# Altium schematic sheet Mainboard.SchDoc(Mainboard)
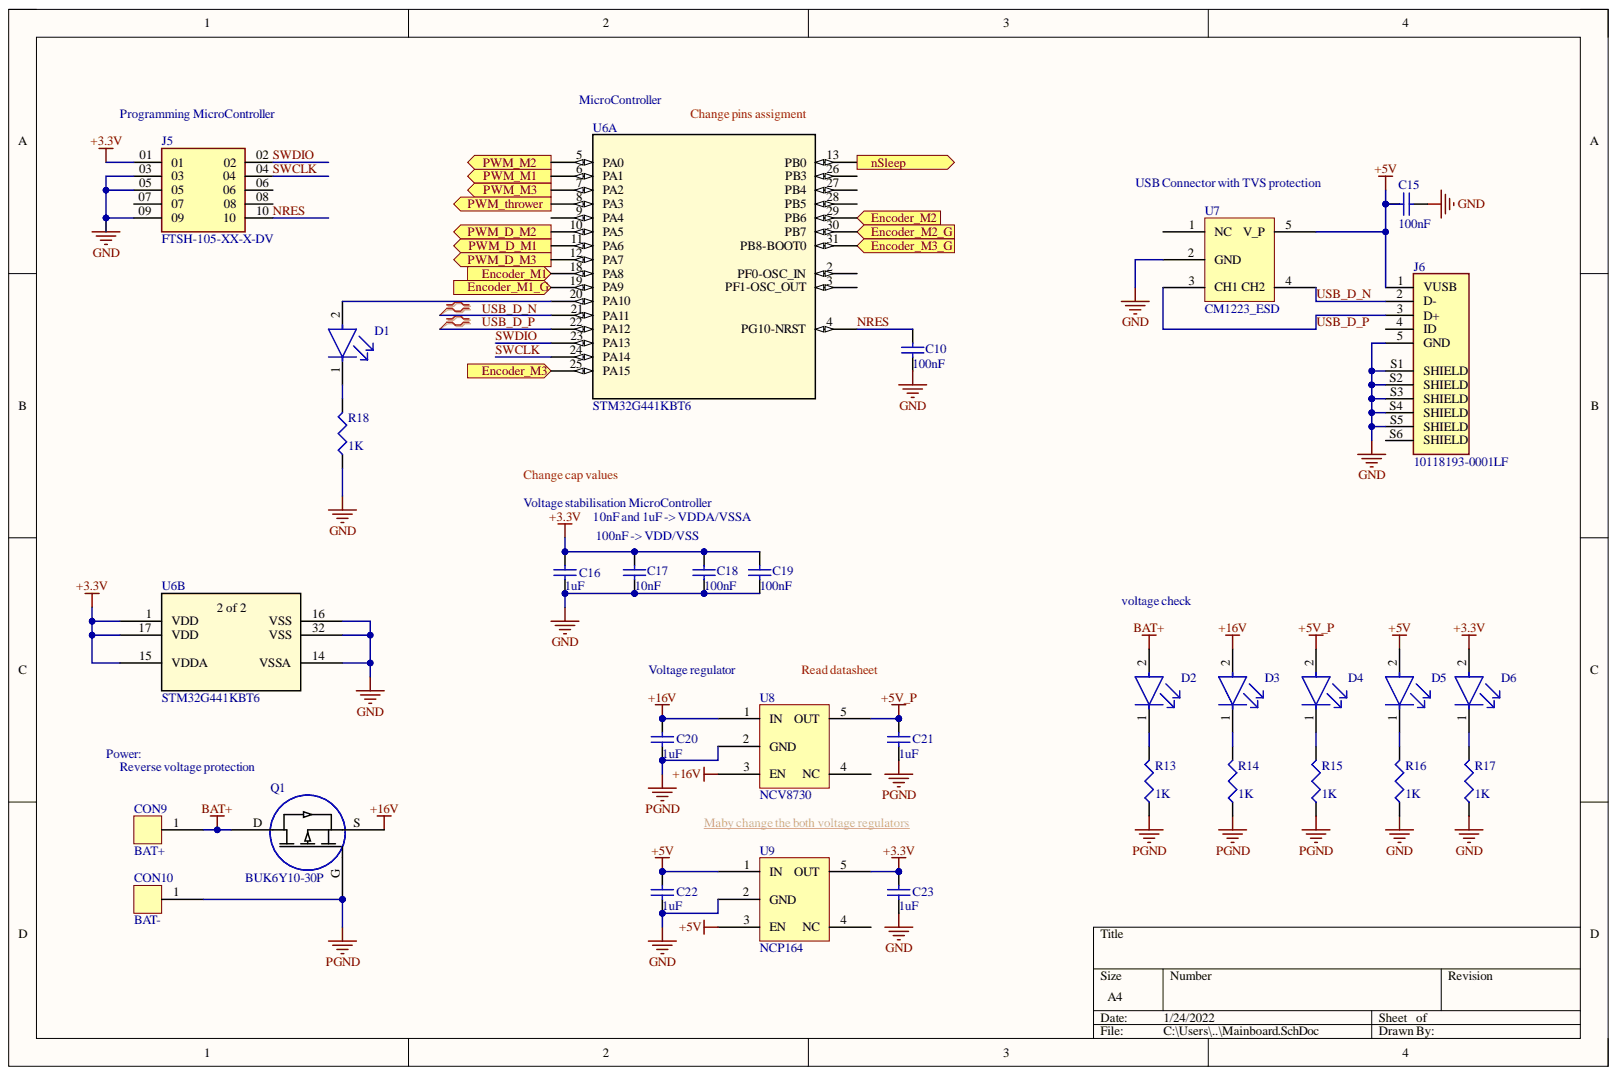
[diagram p0: Mainboard.SchDoc]

## Parts
C10  value 100nF  [1608[0603]]  (hidden comment: Cap Semi)
C15  value 100nF  [1608[0603]]  (hidden comment: Cap Semi)
C16  value 1uF  [1608[0603]]  (hidden comment: Cap Semi)
C17  value 10nF  [1608[0603]]  (hidden comment: Cap Semi)
C18  value 100nF  [1608[0603]]  (hidden comment: Cap Semi)
C19  value 100nF  [1608[0603]]  (hidden comment: Cap Semi)
C20  value 1uF  [C1206]  (hidden comment: Cap Semi)
C21  value 1uF  [C1206]  (hidden comment: Cap Semi)
C22  value 1uF  [C1206]  (hidden comment: Cap Semi)
C23  value 1uF  [C1206]  (hidden comment: Cap Semi)
CON9  BAT+  [con_soldering]
CON10  BAT-  [con_soldering]
D1  LTST-C190GKT  [FP-LTST-C190GKT-MFG]  (value not drawn)
D2  LTST-C190GKT  [FP-LTST-C190GKT-MFG]  (value not drawn)
D3  LTST-C190GKT  [FP-LTST-C190GKT-MFG]  (value not drawn)
D4  LTST-C190GKT  [FP-LTST-C190GKT-MFG]  (value not drawn)
D5  LTST-C190GKT  [FP-LTST-C190GKT-MFG]  (value not drawn)
D6  LTST-C190GKT  [FP-LTST-C190GKT-MFG]  (value not drawn)
J5  FTSH-105-XX-X-DV  [SAMTEC_FTSH-105-XX-X-DV]
J6  10118193-0001LF  [FCI_10118193-0001LF]
Q1  BUK6Y10-30P  [TRANS_PSMN5R5-60YS,115]
R13  value 1K  [J1-0603]  (hidden comment: Res3)
R14  value 1K  [J1-0603]  (hidden comment: Res3)
R15  value 1K  [J1-0603]  (hidden comment: Res3)
R16  value 1K  [J1-0603]  (hidden comment: Res3)
R17  value 1K  [J1-0603]  (hidden comment: Res3)
R18  value 1K  [J1-0603]  (hidden comment: Res3)
U6  STM32G441KBT6  [LQFP-32]  (parts A,B)
U7  CM1223_ESD  [CM1223_ESD]
U8  NCV8730  [NCP164CSN330T1G_Voltage_regulator]
U9  NCP164  [NCP164CSN330T1G_Voltage_regulator]

## Ports
Encoder_M1, Encoder_M1_G, Encoder_M2, Encoder_M2_G, Encoder_M3, Encoder_M3_G, nSleep, PWM_D_M1, PWM_D_M2, PWM_D_M3, PWM_M1, PWM_M2, PWM_M3, PWM_thrower

## Nets
+3.3V: C16.2 C17.2 C18.2 C19.2 C23.2 D6.2 J5.01 U6.1(VDD) U6.15(VDDA) U6.17(VDD) U9.5(OUT)
+5V: C15.2 C22.2 D5.2 J6.1(VUSB) U7.5(V_P) U9.1(IN) U9.3(EN)
+5V_P: C21.2 D4.2 U8.5(OUT)
+16V: C20.2 D3.2 Q1.S U8.1(IN) U8.3(EN)
BAT+: CON9.1 D2.2 Q1.D
GND: C10.1 C15.1 C16.1 C17.1 C18.1 C19.1 C22.1 C23.1 J5.03 J5.05 J5.09 J6.5(GND) J6.S1(SHIELD) J6.S2(SHIELD) J6.S3(SHIELD) J6.S4(SHIELD) J6.S5(SHIELD) R16.1 R17.1 R18.1 U6.14(VSSA) U6.16(VSS) U6.32(VSS) U7.2(GND) U9.2(GND)
PGND: C20.1 C21.1 CON10.1 Q1.G R13.1 R14.1 R15.1 U8.2(GND)
Encoder_M1: U6.18(PA8) ; port Encoder_M1
Encoder_M1_G: U6.19(PA9) ; port Encoder_M1_G
Encoder_M2: U6.29(PB6) ; port Encoder_M2
Encoder_M2_G: U6.30(PB7) ; port Encoder_M2_G
Encoder_M3: U6.25(PA15) ; port Encoder_M3
Encoder_M3_G: U6.31(PB8-BOOT0) ; port Encoder_M3_G
NRES: C10.2 J5.10 U6.4(PG10-NRST)
nSleep: U6.13(PB0) ; port nSleep
PWM_D_M1: U6.11(PA6) ; port PWM_D_M1
PWM_D_M2: U6.10(PA5) ; port PWM_D_M2
PWM_D_M3: U6.12(PA7) ; port PWM_D_M3
PWM_M1: U6.6(PA1) ; port PWM_M1
PWM_M2: U6.5(PA0) ; port PWM_M2
PWM_M3: U6.7(PA2) ; port PWM_M3
PWM_thrower: U6.8(PA3) ; port PWM_thrower
SWCLK: J5.04 U6.24(PA14)
SWDIO: J5.02 U6.23(PA13)
USB_D_N: J6.2(D-) U6.21(PA11) U7.4(CH2)
USB_D_P: J6.3(D+) U6.22(PA12) U7.3(CH1)
NetD1_1: D1.1 R18.2
NetD1_2: D1.2 U6.20(PA10)
NetD2_1: D2.1 R13.2
NetD3_1: D3.1 R14.2
NetD4_1: D4.1 R15.2
NetD5_1: D5.1 R16.2
NetD6_1: D6.1 R17.2

Not connected: J5 06 07 08; J6 4(ID) S6(SHIELD); U6 2(PF0-OSC_IN) 3(PF1-OSC_OUT) 9(PA4) 26(PB3) 27(PB4) 28(PB5); U7 1(NC); U8 4(NC); U9 4(NC)

## Library data of the parts
C10 C15-C23 = Cap Semi: Capacitor (Semiconductor SIM Model); Component Kind: Standard; LatestRevisionDate: 29-May-2009; LatestRevisionNote: IPC-7351 Footprint Added.; Library Name: Miscellaneous Devices.IntLib; PackageDescription: Chip Capacitor; PackageReference: C1206; Published: 8-Jun-2000; Signal Integrity: Cap Semi; Simulation: CAP; Publisher: Altium Limited
CON9 CON10 = Con_soldering: Component Kind: Standard; Library Name: con_soldering.SchLib
D1-D6 = CMP-22017-000005-2: LED GREEN CLEAR CHIP SMD; Dominant Wavelength: 569nm; Forward Current: 30mA; Forward Voltage: 2.1V; Lens Color: Colorless; Luminous Intensity: 6mcd; Number of Elements: 1; Power Dissipation: 100mW; Reverse Voltage: 5V; Test Current: 10mA; Viewing Angle: 130°; Voltage: 2V; Wavelength: 565nm; Case Code (Imperial): 0603; Case Code (Metric): 1608; Case/Package: 0603; Color: Green; Component Kind: Standard; Depth: 0.8mm; Height: 0.8mm; Illumination Color: Green; Lead Free: Lead Free; Length: 1.6mm; Lens Style: Clear; Lens Transparency: Clear; Library Name: Altium Content Vault; Max Operating Temperature: 85°C; Min Operating Temperature: -55°C; Mount: Surface Mount; Number of LEDs: 1; Number of Pins: 2; Package Quantity: 1; Packaging: Tape and Reel; Power Rating: 100mW; Radiation Hardening: No; Width: 0.8mm
J5 = FTSH-105-XX-X-DV: Connector Header Surface Mount 10 position 0.050" (1.27mm); Availability: Unavailable; Component Kind: Standard; Library Name: FTSH-105-XX-X-DV.SchLib; MP: FTSH-105-XX-X-DV; Package: None; Price: None
J6 = 10118193-0001LF: USB - micro B USB 2.0 Receptacle Connector 5 Position Surface Mount, Right Angle; Through Hole; MF: Amphenol ICC; Availability: Unavailable; Component Kind: Standard; Library Name: SnapEDAsch.SchLib; MP: 10118193-0001LF; Package: None; Price: None; SnapEDA_Link: https://www.snapeda.com/parts/10118193-0001LF/Amphenol%20FCI/view-part/2758989/?ref=snap
R13-R18 = Res3: Resistor; Component Kind: Standard; LatestRevisionDate: 29-May-2009; LatestRevisionNote: IPC-7351 Footprint Added.; Library Name: Miscellaneous Devices.IntLib; PackageDescription: Chip Resistor; PackageReference: J1-0603; Published: 8-Jun-2000; Signal Integrity: Res; Simulation: RESISTOR; Publisher: Altium Limited
U6 = STM32G441KBT6: No Description Available; Mfr_Name: STMicroelectronics; Component Kind: Standard; Digi-Key_Part_Number_1: STM32G441KBT6-ND; Library Name: STM32G441KBT6.SchLib; Manufacturer_Part_Number: STM32G441KBT6; RefDes: RefDes; Type: DEV; Copyright: Copyright (C) 2021 Ultra Librarian. All rights reserved.
Q1 = BUK6Y10-30P: Component Kind: Standard; Library Name: BUK6Y10-30P.SchLib
U7 = CM1223_ESD: Component Kind: Standard; Library Name: CM1223_ESD.SchLib
U8 = NCV8730: Component Kind: Standard; Library Name: NCV8730.SchLib
U9 = NCP164: Component Kind: Standard; Library Name: NCP164_voltage_5to3V3.SchLib
